# Altium schematic sheet BLDC_V3.SchDoc
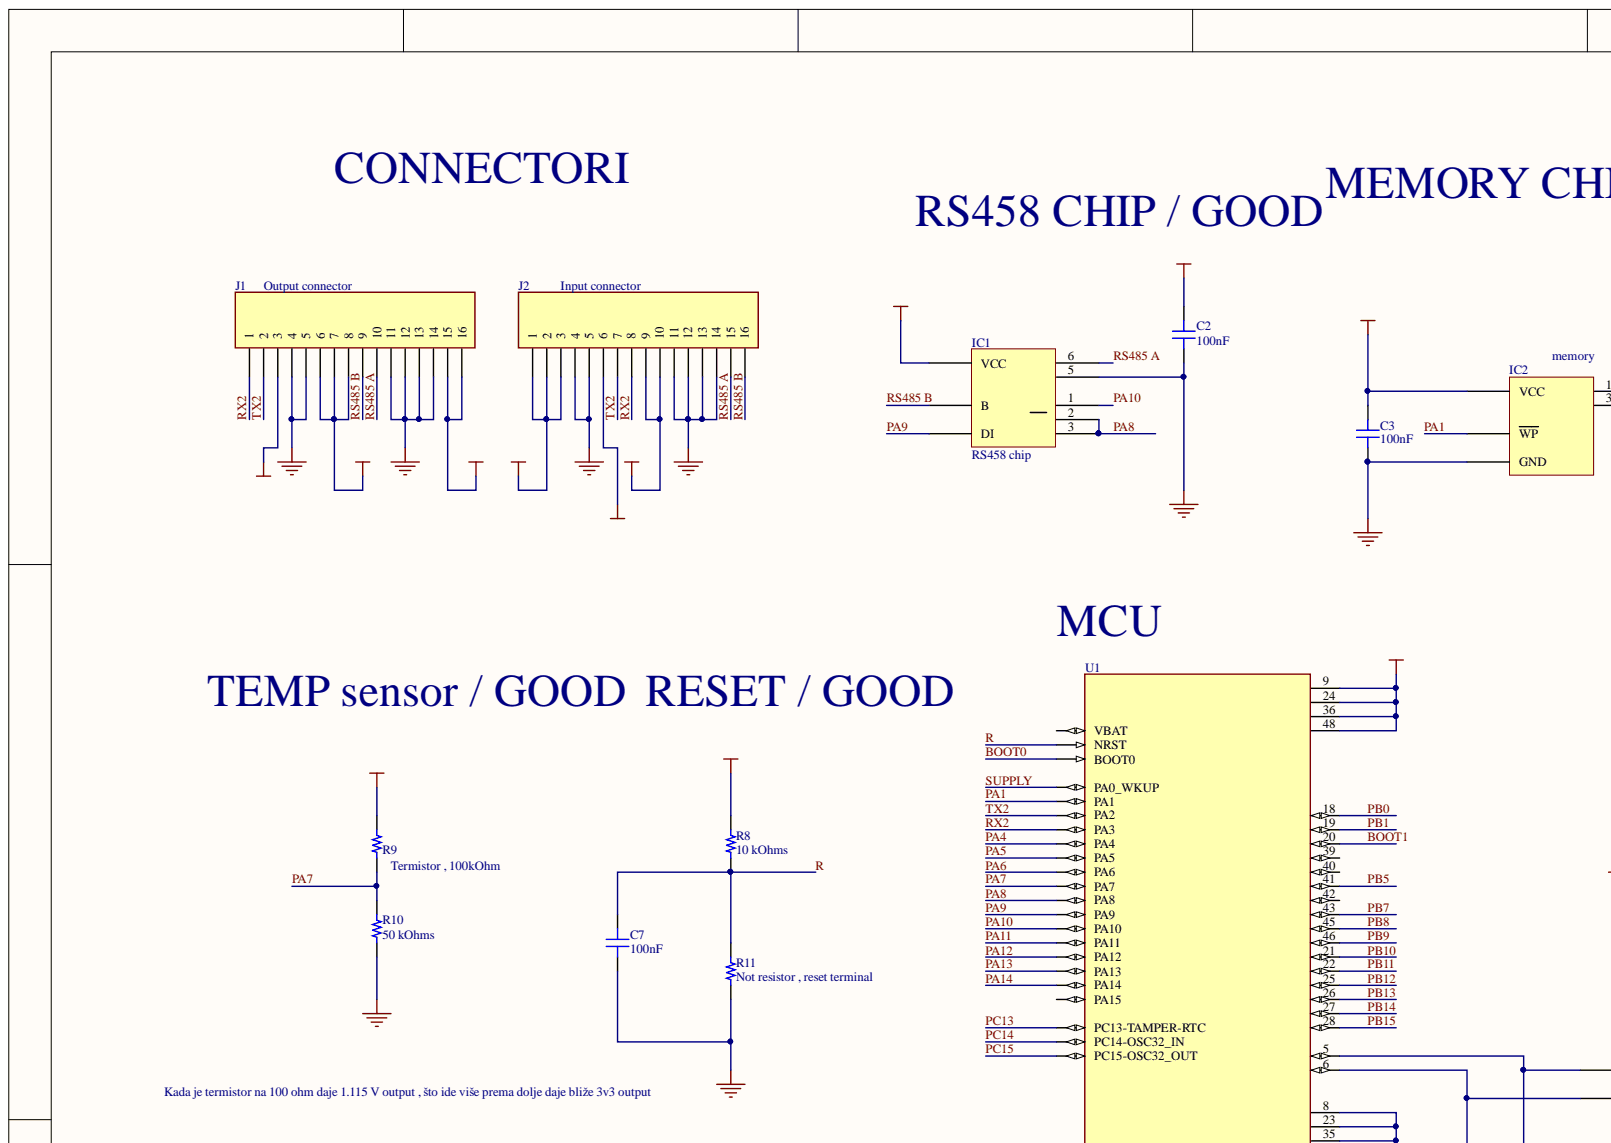
[diagram p0: BLDC_V3.SchDoc, top left]
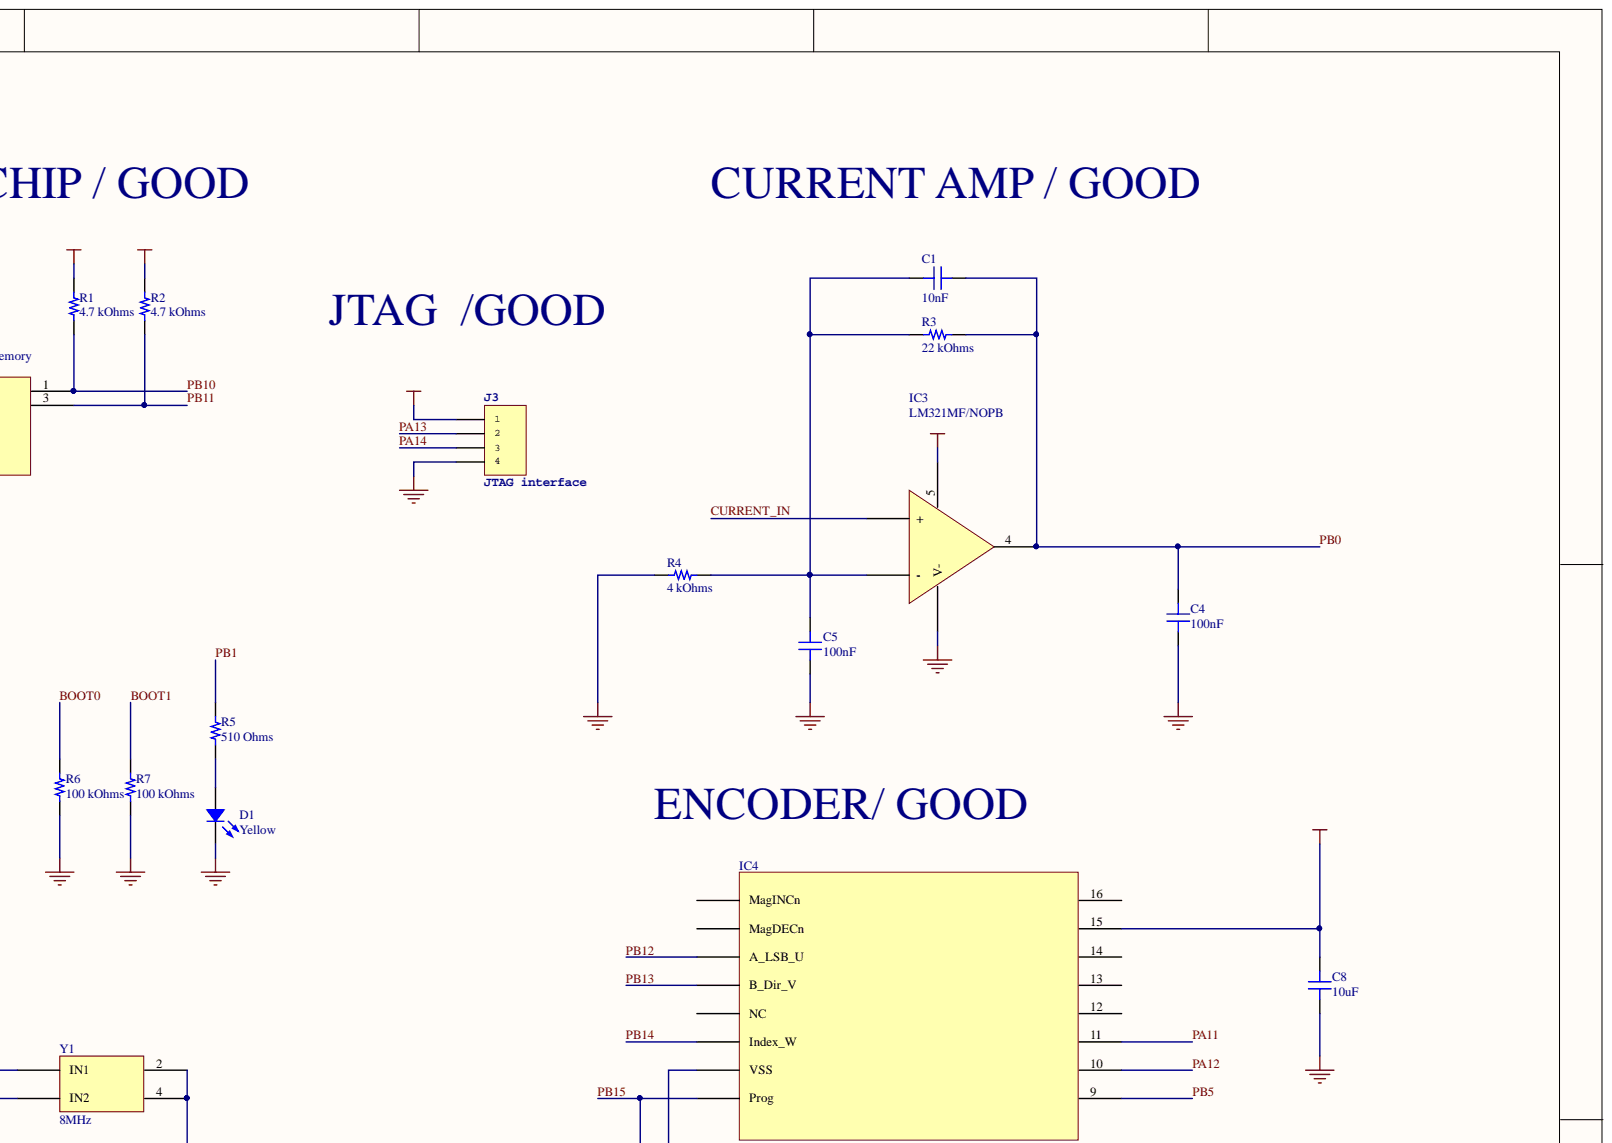
[diagram p1: BLDC_V3.SchDoc, top right]
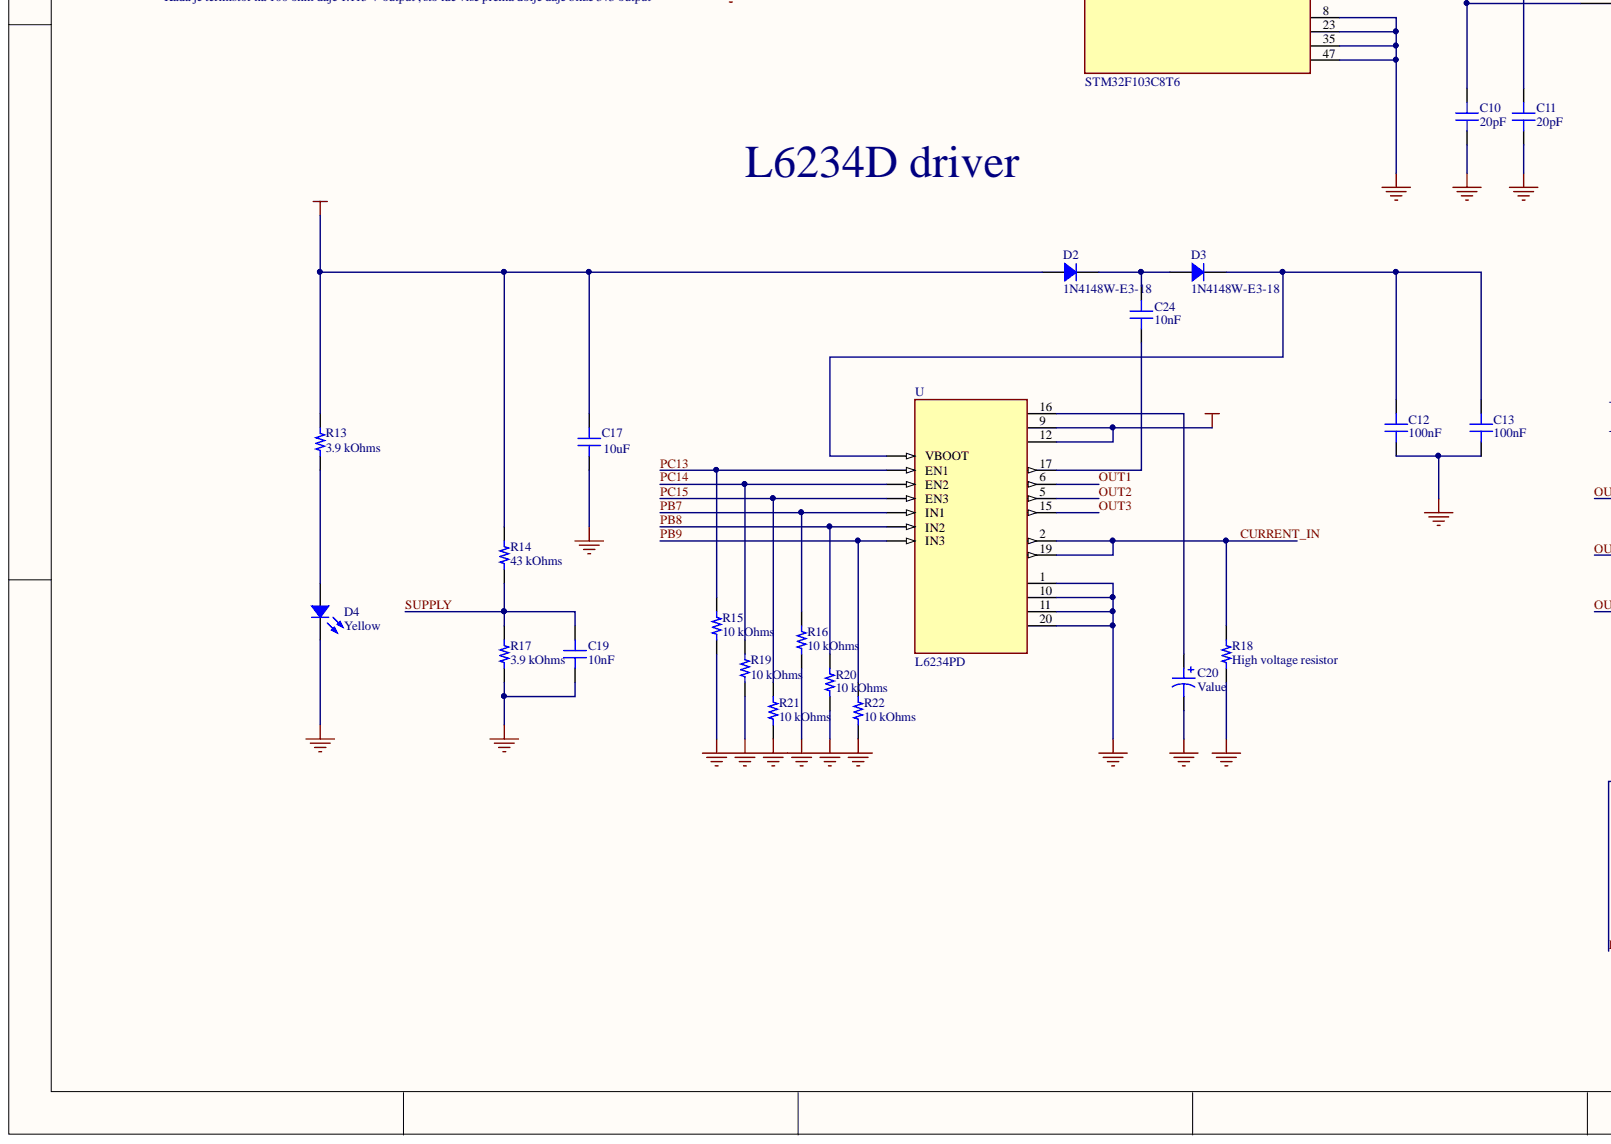
[diagram p2: BLDC_V3.SchDoc, bottom left]
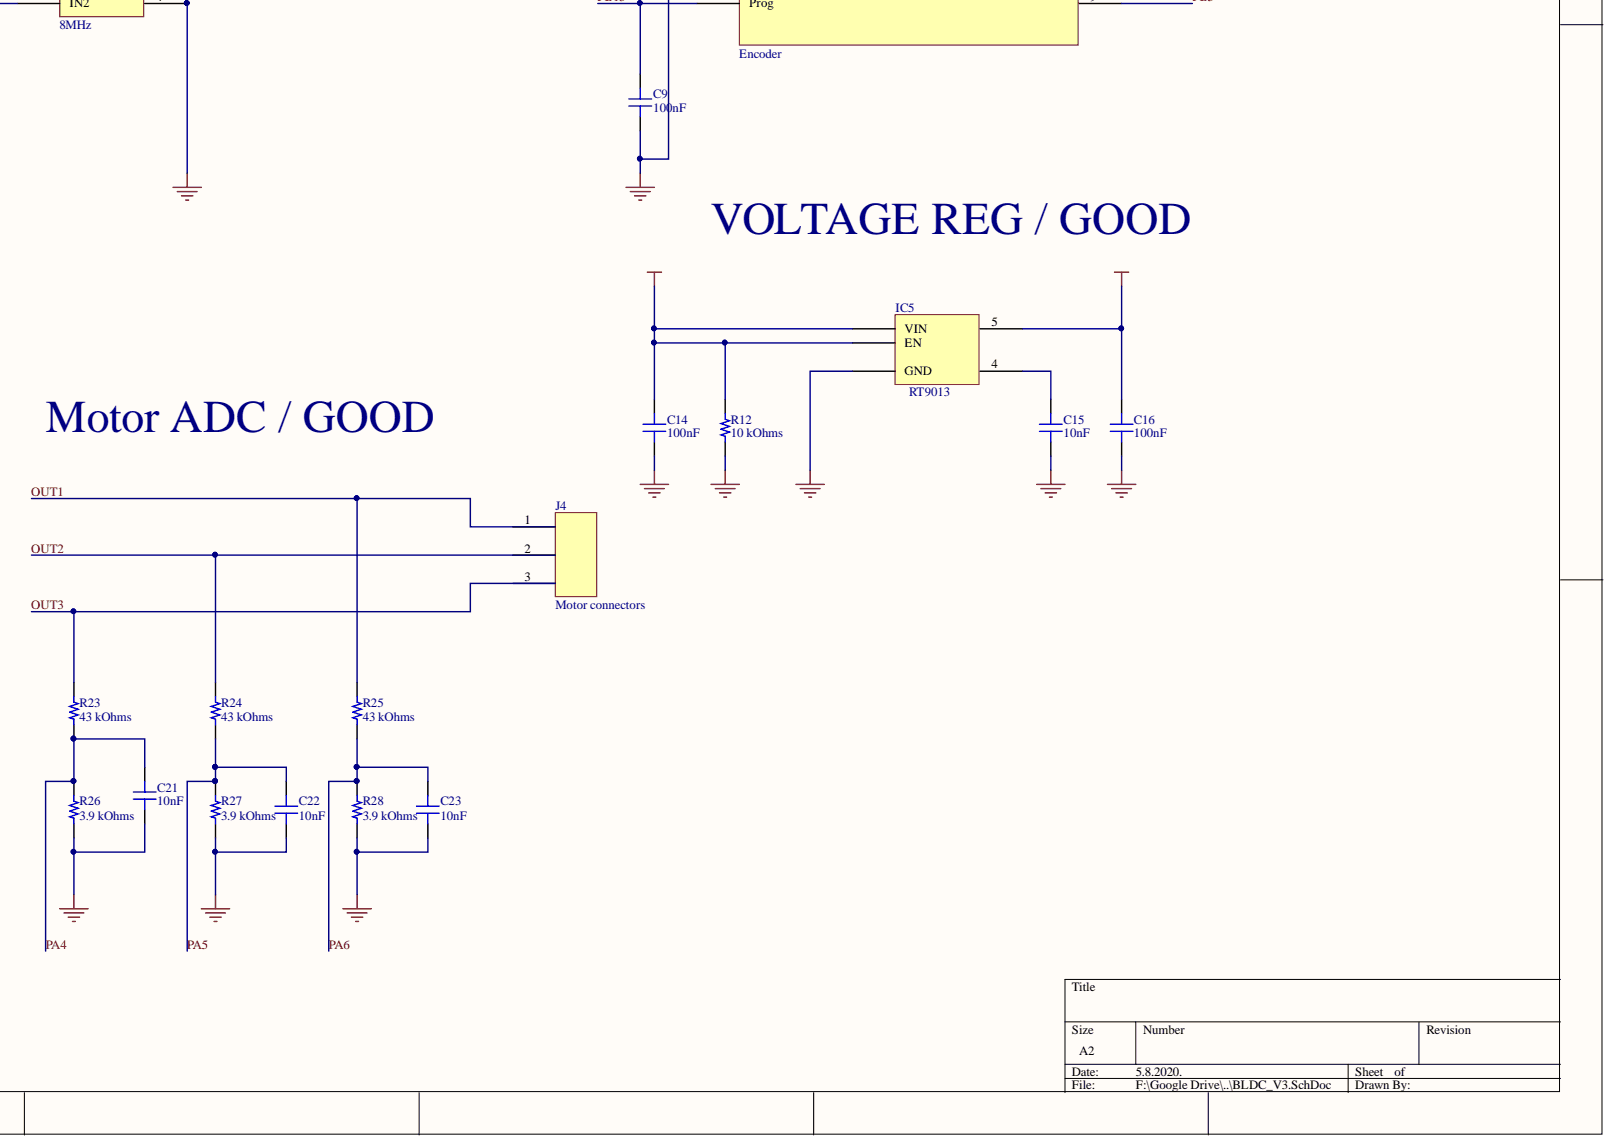
[diagram p3: BLDC_V3.SchDoc, bottom right]

## Parts
C1  10nF  [CAP 0603_1608]
C2  100nF  [CAP 0603_1608]
C3  100nF  [CAP 0603_1608]
C4  100nF  [CAP 0603_1608]
C5  100nF  [CAP 0603_1608]
C7  100nF  [CAP 0603_1608]
C8  10uF  [CAP 0603_1608]
C9  100nF  [CAP 0603_1608]
C10  20pF  [CAP 0603_1608]
C11  20pF  [CAP 0603_1608]
C12  100nF  [CAP 0603_1608]
C13  100nF  [CAP 0603_1608]
C14  100nF  [CAP 0603_1608]
C15  10nF  [CAP 0603_1608]
C16  100nF  [CAP 0603_1608]
C17  10uF  [CAP 1206_3216 - 0.8MM]
C19  10nF  [CAP 0603_1608]
C20  Value  [CAP ALSMD POLY 4.3MM H5.5MM]
C21  10nF  [CAP 0603_1608]
C22  10nF  [CAP 0603_1608]
C23  10nF  [CAP 0603_1608]
C24  10nF  [CAP 0603_1608]
D1  Yellow  [LED 0603_1608 YELLOW]
D2  1N4148W-E3-18  [SOD-123]
D3  1N4148W-E3-18  [SOD-123]
D4  Yellow  [LED 0603_1608 YELLOW]
IC1  Name: RS458 chip  [MICROCHIP SOIC-8 150MIL WIDE]  (hidden comment: 24LC32AT/SN)
IC2  AT24CS04-STUM-T  [MICROCHIP SOT-23-5 OT]  (value not drawn)
IC3  LM321MF/NOPB  [TI SOT-23-5 DBV]
IC4  Encoder  [AS5040 SSOP16]
IC5  Name: RT9013  [TI SOT-23-5 DBV]  (hidden comment: TPS76301QDBVRG4Q1)
J1  Comment_1: Output connector  [TE_826632-8]  (hidden comment: 826632-8)
J2  Comment_1: Input connector  [TE_826632-8]  (hidden comment: None)
J3  JTAG interface  [SAMTEC-HTSW-104-14-X-S]
J4  Motor connectors  [PCBComponent_1]
R1  4.7 kOhms  [RES 0603_1608]
R2  4.7 kOhms  [RES 0603_1608]
R3  22 kOhms  [RES 0603_1608]
R4  4 kOhms  [RES 0603_1608]
R5  510 Ohms  [RES 0603_1608]
R6  100 kOhms  [RES 0603_1608]
R7  100 kOhms  [RES 0603_1608]
R8  10 kOhms  [RES 0603_1608]
R9  Termistor , 100kOhm  [RES 1210_3225]
R10  50 kOhms  [RES 0603_1608]
R11  Not resistor , reset terminal  [RES 0603_1608]
R12  10 kOhms  [RES 0603_1608]
R13  3.9 kOhms  [RES 0603_1608]
R14  43 kOhms  [RES 0603_1608]
R15  10 kOhms  [RES 0603_1608]
R16  10 kOhms  [RES 0603_1608]
R17  3.9 kOhms  [RES 0603_1608]
R18  470 kOhms  [RES 2512_6432]  (value not drawn)
R19  10 kOhms  [RES 0603_1608]
R20  10 kOhms  [RES 0603_1608]
R21  10 kOhms  [RES 0603_1608]
R22  10 kOhms  [RES 0603_1608]
R23  43 kOhms  [RES 0603_1608]
R24  43 kOhms  [RES 0603_1608]
R25  43 kOhms  [RES 0603_1608]
R26  3.9 kOhms  [RES 0603_1608]
R27  3.9 kOhms  [RES 0603_1608]
R28  3.9 kOhms  [RES 0603_1608]
U  L6234PD  [SOIC127P1420X360-21N]
U1  STM32F103C8T6  [QFP50P900X900X160-48N]
Y1  8MHz  [ABRACON ASVTX-09]

## Nets
3V3: C2.2 C3.2 C8.2 C16.2 IC1.8(VCC) IC2.4(VCC) IC4.15 IC5.5 J3.1 R1.2 R2.2 R8.2 R9.2 U1.9 U1.24 U1.36 U1.48
5V: C14.2 IC3.5 IC5.1(VIN) IC5.3(EN) J1.3 J2.6 R12.2
GND: C2.1 C3.1 C4.2 C5.2 C7.1 C8.1 C9.1 C10.1 C11.1 C12.1 C13.1 C14.1 C15.1 C16.1 C17.1 C19.1 C20.2 C21.1 C22.1 C23.1 D1.2 D4.2 IC1.5 IC2.2(GND) IC3.2(V-) IC4.7(VSS) IC5.2(GND) J1.4 J1.5 J1.11 J1.12 J1.13 J1.14 J2.4 J2.5 J2.11 J2.12 J2.13 J2.14 J3.4 R4.2 R6.2 R7.2 R10.1 R11.1 R12.1 R15.1 R16.1 R17.1 R18.1 R19.1 R20.1 R21.1 R22.1 R26.1 R27.1 R28.1 U.1 U.10 U.11 U.20 U1.8 U1.23 U1.35 U1.47 Y1.2 Y1.4
HV: C17.2 D2.1 J1.6 J1.7 J1.8 J1.15 J1.16 J2.1 J2.2 J2.3 J2.9 J2.10 R13.2 R14.2 U.9 U.12
BOOT0: R6.1 U1.44(BOOT0)
BOOT1: R7.1 U1.20
CURRENT_IN: IC3.1(+) R18.2 U.2 U.19
OUT1: J4.1 R25.2 U.6
OUT2: J4.2 R24.2 U.5
OUT3: J4.3 R23.2 U.15
PA1: IC2.5(WP) U1.11(PA1)
PA4: C21.2 R23.1 R26.2 U1.14(PA4)
PA5: C22.2 R24.1 R27.2 U1.15(PA5)
PA6: C23.2 R25.1 R28.2 U1.16(PA6)
PA7: R9.1 R10.2 U1.17(PA7)
PA8: IC1.2 IC1.3 U1.29(PA8)
PA9: IC1.4(DI) U1.30(PA9)
PA10: IC1.1 U1.31(PA10)
PA11: IC4.11 U1.32(PA11)
PA12: IC4.10 U1.33(PA12)
PA13: J3.2 U1.34(PA13)
PA14: J3.3 U1.37(PA14)
PB0: C1.1 C4.1 IC3.4 R3.1 U1.18
PB1: R5.2 U1.19
PB5: IC4.9 U1.41
PB7: R16.2 U.7(IN1) U1.43
PB8: R20.2 U.4(IN2) U1.45
PB9: R22.2 U.14(IN3) U1.46
PB10: IC2.1 R1.1 U1.21
PB11: IC2.3 R2.1 U1.22
PB12: IC4.3(A_LSB_U) U1.25
PB13: IC4.4(B_Dir_V) U1.26
PB14: IC4.6(Index_W) U1.27
PB15: C9.2 IC4.8(Prog) U1.28
PC13: R15.2 U.8(EN1) U1.2(PC13-TAMPER-RTC)
PC14: R19.2 U.3(EN2) U1.3(PC14-OSC32_IN)
PC15: R21.2 U.13(EN3) U1.4(PC15-OSC32_OUT)
R: C7.2 R8.1 R11.2 U1.7(NRST)
RS485 A: IC1.6 J1.10 J2.15
RS485 B: IC1.7(B) J1.9 J2.16
RX2: J1.1 J2.8 U1.13(PA3)
SUPPLY: C19.2 R14.1 R17.2 U1.10(PA0_WKUP)
TX2: J1.2 J2.7 U1.12(PA2)
NetC1_2: C1.2 C5.1 IC3.3(-) R3.2 R4.1
NetC10_2: C10.2 U1.6 Y1.3(IN2)
NetC11_2: C11.2 U1.5 Y1.1(IN1)
NetC12_2: C12.2 C13.2 D3.2 U.18(VBOOT)
NetC15_2: C15.2 IC5.4
NetC20_1: C20.1 U.16
NetC24_1: C24.1 U.17
NetC24_2: C24.2 D2.2 D3.1
NetD1_1: D1.1 R5.1
NetD4_1: D4.1 R13.1

Not connected: IC4 1(MagINCn) 2(MagDECn) 5(NC) 12 13 14 16; U1 1(VBAT) 38(PA15) 39 40 42

## Library data of the parts
C1-C5 C7-C9 C12-C16 C19 C21-C24 = GCM188R72A223KA37D: CAP CER 0.022UF 100V X7R 0603; Manufacturer: Murata Electronics; Capacitance: 20pF; Database Table Name: Capacitors - Ceramic - 0603; Tolerance: ±10%; Applications: Automotive; Component Kind: Standard; ComponentLink1Description: Datasheet; ComponentLink1URL: https://www.murata.com/~/media/webrenewal/support/library/catalog/products/capacitor/mlcc/c03e.ashx?la=en-us; ComponentLink2Description: DigiKey Link; ComponentLink2URL: http://digikey.com/product-detail/en/murata-electronics/GCM188R72A223KA37D/490-4782-1-ND/1641701; Device Package: 0603 (1608 Metric); LastUpdated: 21.1.2020. 0:02:09; Library Name: Celestial Altium Library - altium_library.DbLib; Lifecycle Status: Active; Minimum Order: 1; Mounting Type: Surface Mount, MLCC; Operating Temperature: -55°C ~ 125°C; Package / Case: 0603 (1608 Metric); Packaging: TapeAndReel; PartId: 2242; Part Number: GCM188R72A223KA37D; Part Status: Active; Price: 0.03; Ratings: AEC-Q200; Series: GCM; Size / Dimension: 0.063" L x 0.031" W (1.60mm x 0.80mm); Supplier 1: DigiKey; Supplier Part Number 1: 490-4782-1-ND; Temperature Coefficient: X7R; Thickness (Max): 0.035" (0.90mm); Voltage - Rated: 100V
  C1 C15 C19 C21-C24: Value: 10nF
  C2-C5 C7 C9 C12-C14 C16: Value: 100nF
  C8: Value: 10uF
C10 C11 = GCM188R72A223KA37D: CAP CER 0.022UF 100V X7R 0603; Manufacturer: Murata Electronics; Database Table Name: Capacitors - Ceramic - 0603; Tolerance: ±10%; Applications: Automotive; Capacitance: 20pF; Component Kind: Standard; ComponentLink1Description: Datasheet; ComponentLink1URL: https://www.murata.com/~/media/webrenewal/support/library/catalog/products/capacitor/mlcc/c03e.ashx?la=en-us; ComponentLink2Description: DigiKey Link; ComponentLink2URL: http://digikey.com/product-detail/en/murata-electronics/GCM188R72A223KA37D/490-4782-1-ND/1641701; Device Package: 0603 (1608 Metric); LastUpdated: 21.1.2020. 0:02:09; Library Name: Celestial Altium Library - altium_library.DbLib; Lifecycle Status: Active; Minimum Order: 1; Mounting Type: Surface Mount, MLCC; Operating Temperature: -55°C ~ 125°C; Package / Case: 0603 (1608 Metric); Packaging: TapeAndReel; PartId: 2242; Part Number: GCM188R72A223KA37D; Part Status: Active; Price: 0.03; Ratings: AEC-Q200; Series: GCM; Size / Dimension: 0.063" L x 0.031" W (1.60mm x 0.80mm); Supplier 1: DigiKey; Supplier Part Number 1: 490-4782-1-ND; Temperature Coefficient: X7R; Thickness (Max): 0.035" (0.90mm); Value: 20pF; Voltage - Rated: 100V
C17 = CC1206KRX7R0BB152: CAP CER 1500PF 100V X7R 1206; Database Table Name: Capacitors - Ceramic - 1206; Component Kind: Standard; Library Name: Celestial Altium Library - altium_library.DbLib; Part Number: CC1206KRX7R0BB152; Value: 10uF
C20 = UWX1V4R7MCL1GB: CAP ALUM 4.7UF 20% 35V SMD; Database Table Name: Capacitors - Aluminum - Electrolytic SMT; Component Kind: Standard; Library Name: Celestial Altium Library - altium_library.DbLib; Part Number: UWX1V4R7MCL1GB
D1 D4 = APTD1608SYCK/J3-PF: LED YELLOW CLEAR 2SMD; Manufacturer: Kingbright; Configuration: Standard; Current - Test: 20mA; Database Table Name: LED - Indication; Lens Color: Colorless; Lens Size: 0.70mm Dia; Lens Style: Round with Domed Top; Millicandela Rating: 800mcd; Viewing Angle: 60°; Voltage - Forward (Vf) (Typ): 2V; Wavelength - Dominant: 590nm; Color: Yellow; Component Kind: Standard; ComponentLink1Description: Datasheet; ComponentLink1URL: http://www.kingbrightusa.com/images/catalog/SPEC/APTD1608SYCK-J3-PF.pdf; ComponentLink2Description: DigiKey Link; ComponentLink2URL: http://digikey.com/product-detail/en/kingbright/APTD1608SYCK-J3-PF/754-1803-1-ND/4753648; Device Package: 0603 (1608 Metric); Height (Max): 1.10mm; LastUpdated: 21.1.2020. 3:14:59; Lens Transparency: Clear; Library Name: Celestial Altium Library - altium_library.DbLib; Lifecycle Status: Active; Minimum Order: 1; Mounting Type: Surface Mount; Package / Case: 0603 (1608 Metric); Packaging: TapeAndReel; PartId: 159; Part Number: APTD1608SYCK/J3-PF; Part Status: Active; Price: 0.16; Series: -; Size / Dimension: 1.60mm L x 0.80mm W; Supplier 1: DigiKey; Supplier Device Package: 2-SMD; Supplier Part Number 1: 754-1803-1-ND; Value: Yellow; Wavelength - Peak: 590nm
D2 D3 = 1N4148W-E3-18: DIODE GEN PURP 75V 150MA SOD123; Manufacturer: Vishay Semiconductor Diodes Division; Capacitance @ Vr, F: 4pF @ 0V, 1MHz; Current - Reverse Leakage @ Vr: 100µA @ 100V; Database Table Name: Diodes - Rectifiers - Single; Diode Type: Standard; Reverse Recovery Time (trr): 4ns; Speed: Small Signal =< 200mA (Io), Any Speed; Voltage - Forward (Vf) (Max) @ If: 1.2V @ 100mA; Component Kind: Standard; ComponentLink1Description: Datasheet; ComponentLink1URL: http://www.vishay.com/docs/85748/1n4148w.pdf; ComponentLink2Description: DigiKey Link; ComponentLink2URL: http://digikey.com/product-detail/en/vishay-semiconductor-diodes-division/1N4148W-E3-18/1N4148W-E3-18CT-ND/3104406; Current - Average Rectified (Io): 150mA; Device Package: SOD-123; LastUpdated: 21.1.2020. 2:42:40; Library Name: Celestial Altium Library - altium_library.DbLib; Lifecycle Status: Active; Minimum Order: 1; Mounting Type: Surface Mount; Operating Temperature - Junction: 150°C (Max); Package / Case: SOD-123; Packaging: TapeAndReel; PartId: 1019; Part Number: 1N4148W-E3-18; Part Status: Active; Price: 0.03; Series: -; Supplier 1: DigiKey; Supplier Device Package: SOD-123; Supplier Part Number 1: 1N4148W-E3-18CT-ND; Voltage - DC Reverse (Vr) (Max): 75V
IC1 = 24LC32AT/SN: IC EEPROM 32K I2C 400KHZ 8SOIC; Manufacturer: Microchip Technology; Access Time: 900ns; Database Table Name: Memory; Memory Interface: I²C; Memory Size: 32Kb (4K x 8); Memory Type: Non-Volatile; Voltage - Supply: 2.5V ~ 5.5V; Write Cycle Time - Word, Page: 5ms; Clock Frequency: 400kHz; Component Kind: Standard; ComponentLink1Description: Datasheet; ComponentLink1URL: http://www.microchip.com/mymicrochip/filehandler.aspx?ddocname=en011853; ComponentLink2Description: DigiKey Link; ComponentLink2URL: http://digikey.com/product-detail/en/microchip-technology/24LC32AT-SN/24LC32AT-SNCT-ND/8345666; Device Package: 8-SOIC (0.154", 3.90mm Width); LastUpdated: 21.1.2020. 3:33:43; Library Name: Celestial Altium Library - altium_library.DbLib; Lifecycle Status: Active; Memory Format: EEPROM; Minimum Order: 1; Mounting Type: Surface Mount; Operating Temperature: 0°C ~ 70°C (TA); Package / Case: 8-SOIC (0.154", 3.90mm Width); Packaging: TapeAndReel; PartId: 8; Part Number: 24LC32AT/SN; Part Status: Active; Price: 0.31; Series: -; Supplier 1: DigiKey; Supplier Device Package: 8-SOIC; Supplier Part Number 1: 24LC32AT/SNCT-ND; Technology: EEPROM
IC2 = AT24CS04-STUM-T: IC EEPROM 4K I2C 1MHZ SOT23-5; Manufacturer: Microchip Technology; Access Time: 550ns; Database Table Name: Memory; Memory Interface: I²C; Memory Size: 4Kb (512 x 8); Memory Type: Non-Volatile; Voltage - Supply: 1.7V ~ 5.5V; Write Cycle Time - Word, Page: 5ms; : memory; Clock Frequency: 1MHz; Component Kind: Standard; ComponentLink1Description: Datasheet; ComponentLink1URL: http://www.microchip.com/mymicrochip/filehandler.aspx?ddocname=en589808; ComponentLink2Description: DigiKey Link; ComponentLink2URL: http://digikey.com/product-detail/en/microchip-technology/AT24CS04-STUM-T/AT24CS04-STUM-TCT-ND/3973043; Device Package: SOT-23-5 Thin, TSOT-23-5; LastUpdated: 21.1.2020. 3:34:36; Library Name: Celestial Altium Library - altium_library.DbLib; Lifecycle Status: Active; Memory Format: EEPROM; Minimum Order: 1; Mounting Type: Surface Mount; Operating Temperature: -40°C ~ 85°C (TA); Package / Case: SOT-23-5 Thin, TSOT-23-5; Packaging: TapeAndReel; PartId: 852; Part Number: AT24CS04-STUM-T; Part Status: Active; Price: 0.24; Series: -; Supplier 1: DigiKey; Supplier Device Package: SOT-23-5; Supplier Part Number 1: AT24CS04-STUM-TCT-ND; Technology: EEPROM
IC3 = LM321MF/NOPB: IC OPAMP GP 1 CIRCUIT SOT23-5; Manufacturer: Texas Instruments; Amplifier Type: General Purpose; Current - Input Bias: 45nA; Current - Output / Channel: 40mA; Current - Supply: 660µA; Database Table Name: Amplifiers - OP Amps and Buffer Amps; Gain Bandwidth Product: 1MHz; Number of Circuits: 1; Slew Rate: 0.4V/µs; Voltage - Input Offset: 2mV; Voltage - Supply, Single/Dual (±): 3V ~ 30V, ±1.5V ~ 15V; Component Kind: Standard; ComponentLink1Description: Datasheet; ComponentLink1URL: http://www.ti.com/general/docs/suppproductinfo.tsp?distId=10&gotoUrl=http%3A%2F%2Fwww.ti.com%2Flit%2Fgpn%2Flm321; ComponentLink2Description: DigiKey Link; ComponentLink2URL: http://digikey.com/product-detail/en/texas-instruments/LM321MF-NOPB/LM321MF-NOPBCT-ND/638699; Device Package: SC-74A, SOT-753; LastUpdated: 9.1.2020. 2:04:15; Library Name: Celestial Altium Library - altium_library.DbLib; Lifecycle Status: Active; Minimum Order: 1; Mounting Type: Surface Mount; Operating Temperature: -40°C ~ 85°C; Package / Case: SC-74A, SOT-753; Packaging: ReReel; PartId: 12; Part Number: LM321MF/NOPB; Part Status: Active; Price: 0.00; Series: -; Supplier 1: DigiKey; Supplier Device Package: SOT-23-5; Supplier Part Number 1: LM321MF/NOPBCT-ND
IC4 = Component_1: AS5040 ENCODER; Component Kind: Standard; Library Name: AS5040_costum.SchLib
IC5 = TPS76301QDBVRG4Q1: IC REG LIN POS ADJ 150MA SOT23-5; Manufacturer: Texas Instruments; Control Features: Enable; Current - Quiescent (Iq): 100µA; Current - Supply (Max): 140µA; Database Table Name: Voltage Regulators - Linear; Number of Regulators: 1; Output Configuration: Positive; Output Type: Adjustable; Protection Features: Over Current, Over Temperature, Reverse Polarity; PSRR: 60dB (1kHz); Voltage - Input (Max): 10V; Voltage - Output (Max): 6.5V; Voltage - Output (Min/Fixed): 1.5V; Component Kind: Standard; ComponentLink1Description: Datasheet; ComponentLink1URL: http://www.ti.com/general/docs/suppproductinfo.tsp?distId=10&gotoUrl=http%3A%2F%2Fwww.ti.com%2Flit%2Fgpn%2Ftps763-q1; ComponentLink2Description: DigiKey Link; ComponentLink2URL: http://digikey.com/product-detail/en/texas-instruments/TPS76301QDBVRG4Q1/296-24437-1-ND/2057495; Current - Output: 150mA; Device Package: SC-74A, SOT-753; LastUpdated: 18.1.2020. 20:03:00; Library Name: Celestial Altium Library - altium_library.DbLib; Lifecycle Status: Active; Minimum Order: 1; Mounting Type: Surface Mount; Operating Temperature: -40°C ~ 125°C; Package / Case: SC-74A, SOT-753; Packaging: TapeAndReel; Part Number: TPS76301QDBVRG4Q1; Part Status: Active; Price: 0.39; Series: Automotive, AEC-Q100; Supplier 1: DigiKey; Supplier Device Package: SOT-23-5; Supplier Part Number 1: 296-24437-1-ND
J1 J2 = 826632-8: Pin header; pin strips; AMPMODU MOD II; male; PIN: 16; straight; Centerline_Pitch: 2.54 mm[.1 in]; MF: TE Connectivity; Number_of_Positions: 16; Product_Type: Connector; Availability: Unavailable; Component Kind: Standard; EU_RoHS_Compliance: Compliant; Library Name: 8x2_pin_header.IntLib; MP: 826632-8; Package: None; Price: None; SnapEDA_Link: https://www.snapeda.com/parts/826632-8/TE%20Connectivity%20AMP%20Connectors/view-part/504364/?ref=snap; TE_purchase_URL: https://www.te.com/usa-en/product-826632-8.html?te_bu=Cor&te_type=disp&te_campaign=seda_glo_cor-seda-global-disp-prtnr-fy19-seda-model-bom-cta_sma-317_1&elqCampaignId=32493
J3 = SAMTEC-HTSW-104-14-X-SJ: Component Kind: Standard; Library Name: SAMTEC-HTSW-104-14-X-S.IntLib
J4 = Component_1: Component Kind: Standard; Library Name: motor_connector_schematic.SchLib
R1-R8 R10-R17 R19-R28 = CPF0603B1K0E: RES SMD 1K OHM 0.1% 1/16W 0603; Manufacturer: TE Connectivity Passive Product; Database Table Name: Resistors - Surface Mount - 0603; Power (Watts): 0.063W, 1/16W; Resistance: 1 kOhms; Temperature Coefficient: ±25ppm/°C; Component Kind: Standard; ComponentLink1Description: Datasheet; ComponentLink1URL: https://www.te.com/commerce/DocumentDelivery/DDEController?Action=srchrtrv&DocNm=1773200&DocType=DS&DocLang=English; ComponentLink2Description: DigiKey Link; ComponentLink2URL: http://digikey.com/product-detail/en/te-connectivity-passive-product/CPF0603B1K0E/A119887CT-ND/4966485; Composition: Thin Film; Device Package: 0603 (1608 Metric); Height - Seated (Max): 0.022" (0.55mm); LastUpdated: 21.1.2020. 0:24:55; Library Name: Celestial Altium Library - altium_library.DbLib; Lifecycle Status: Active; Minimum Order: 1; Number of Terminations: 2; Operating Temperature: -55°C ~ 155°C; Package / Case: 0603 (1608 Metric); Packaging: TapeAndReel; PartId: 4877; Part Number: CPF0603B1K0E; Part Status: Active; Price: 0.09; Series: CPF, Neohm; Size / Dimension: 0.061" L x 0.031" W (1.55mm x 0.80mm); Supplier 1: DigiKey; Supplier Device Package: 0603; Supplier Part Number 1: A119887CT-ND; Tolerance: ±0.1%
  R1 R2: Value: 4.7 kOhms
  R3: Value: 22 kOhms
  R4: Value: 4 kOhms
  R5: Value: 510 Ohms
  R6 R7: Value: 100 kOhms
  R8 R12 R15 R16 R19-R22: Value: 10 kOhms
  R10: Value: 50 kOhms
  R11: Value: Not resistor , reset terminal
  R13 R17 R26-R28: Value: 3.9 kOhms
  R14 R23-R25: Value: 43 kOhms
R9 = ERJ-14BQJR39U: RES 0.39 OHM 5% 1/2W 1210; Manufacturer: Panasonic Electronic Components; Database Table Name: Resistors - Surface Mount - 1210; Power (Watts): 0.5W, 1/2W; Resistance: 390 mOhms; Temperature Coefficient: ±200ppm/°C; Value: 390 mOhms; Component Kind: Standard; ComponentLink1Description: Datasheet; ComponentLink1URL: https://industrial.panasonic.com/cdbs/www-data/pdf/RDN0000/AOA0000C313.pdf; ComponentLink2Description: DigiKey Link; ComponentLink2URL: http://digikey.com/product-detail/en/panasonic-electronic-components/ERJ-14BQJR39U/P17317CT-ND/5647801; Composition: Thick Film; Device Package: 1210 (3225 Metric); Features: Automotive AEC-Q200, Current Sense; Height - Seated (Max): 0.028" (0.70mm); LastUpdated: 21.1.2020. 4:16:04; Library Name: Celestial Altium Library - altium_library.DbLib; Lifecycle Status: Active; Minimum Order: 1; Number of Terminations: 2; Operating Temperature: -55°C ~ 125°C; Package / Case: 1210 (3225 Metric); Packaging: TapeAndReel; Part Number: ERJ-14BQJR39U; Part Status: Active; Price: 0.08; Ratings: AEC-Q200; Series: ERJ; Size / Dimension: 0.126" L x 0.098" W (3.20mm x 2.50mm); Supplier 1: DigiKey; Supplier Device Package: 1210; Supplier Part Number 1: P17317CT-ND; Tolerance: ±5%
R18 = HV733ATTE4703F: SMD RESISTOR; Manufacturer: KOA Speer Electronics, Inc.; Database Table Name: Resistors - Surface Mount - 2512; Name: High voltage resistor; Power (Watts): 1W; Temperature Coefficient: ±100ppm/°C; Tolerance: ±1%; Component Kind: Standard; ComponentLink1Description: Datasheet; ComponentLink1URL: //media.digikey.com/pdf/Data%20Sheets/KOA%20Speer/HV73_Series.pdf; ComponentLink2Description: DigiKey Link; ComponentLink2URL: http://digikey.com/product-detail/en/koa-speer-electronics-inc/HV733ATTE4703F/2019-HV733ATTE4703FCT-ND/10134573; Composition: Thick Film; Device Package: 2512 (6432 Metric); Features: High Voltage, Moisture Resistant; Height - Seated (Max): 0.028" (0.70mm); LastUpdated: 21.1.2020. 4:26:27; Library Name: Celestial Altium Library - altium_library.DbLib; Lifecycle Status: Active; Minimum Order: 1; Number of Terminations: 2; Operating Temperature: -55°C ~ 155°C; Package / Case: 2512 (6432 Metric); Packaging: TapeAndReel; Part Number: HV733ATTE4703F; Part Status: Active; Price: 0.27; Resistance: 470 kOhms; Series: HV73; Size / Dimension: 0.248" L x 0.122" W (6.30mm x 3.10mm); Supplier 1: DigiKey; Supplier Device Package: 2512; Supplier Part Number 1: 2019-HV733ATTE4703FCT-ND; Value: 470 kOhms
U = L6234PD: Motor Driver BiCDMOS Parallel PowerSO-20; MF: STMicroelectronics; Availability: Unavailable; Component Kind: Standard; Library Name: L6234PD.IntLib; MP: L6234PD; Package: SOIC-20 STMicroelectronics; Price: None; SnapEDA_Link: https://www.snapeda.com/parts/L6234PD/STMicroelectronics/view-part/161873/?ref=snap
U1 = STM32F103C8T6: ARMÂ® CortexÂ®-M3 STM32F1 Microcontroller IC 32-Bit 72MHz 64KB _64K x 8_ FLASH; MF: STMicroelectronics; Availability: Unavailable; Component Kind: Standard; Library Name: STM32F103C8T6_pro.IntLib; MP: STM32F103C8T6; Package: LQFP-48 STMicroelectronics; Price: None; SnapEDA_Link: https://www.snapeda.com/parts/STM32F103C8T6/STMicroelectronics/view-part/52195/?ref=snap
Y1 = ASVTX-09-12.800MHZ-T: XTAL OSC VCTCXO 12.8000MHZ SNWV; Manufacturer: Abracon LLC; Base Resonator: Crystal; Current - Supply (Max): 2mA; Database Table Name: Oscillators - Oscillators; Frequency: 12.8MHz; Frequency Stability: ±2.5ppm; Output: Clipped Sine Wave; Voltage - Supply: 3V; Component Kind: Standard; ComponentLink1Description: Datasheet; ComponentLink1URL: https://abracon.com/Oscillators/ASVTX-09.pdf; ComponentLink2Description: DigiKey Link; ComponentLink2URL: http://digikey.com/product-detail/en/abracon-llc/ASVTX-09-12.800MHZ-T/535-9333-1-ND/675754; Device Package: 4-SMD, No Lead; Height - Seated (Max): 0.063" (1.60mm); LastUpdated: 21.1.2020. 3:22:19; Library Name: Celestial Altium Library - altium_library.DbLib; Lifecycle Status: Active; Minimum Order: 1; Mounting Type: Surface Mount; Operating Temperature: -30°C ~ 75°C; Package / Case: 4-SMD, No Lead; Packaging: TapeAndReel; PartId: 241; Part Number: ASVTX-09-12.800MHZ-T; Part Status: Active; Price: 2.70; Series: ASVTX-09; Size / Dimension: 0.197" L x 0.126" W (5.00mm x 3.20mm); Supplier 1: DigiKey; Supplier Part Number 1: 535-9333-1-ND; Type: VCTCXO; Value: 8MHz
